# Altium schematic sheet [13] - SD CARD.SchDoc("[13] - SD CARD")
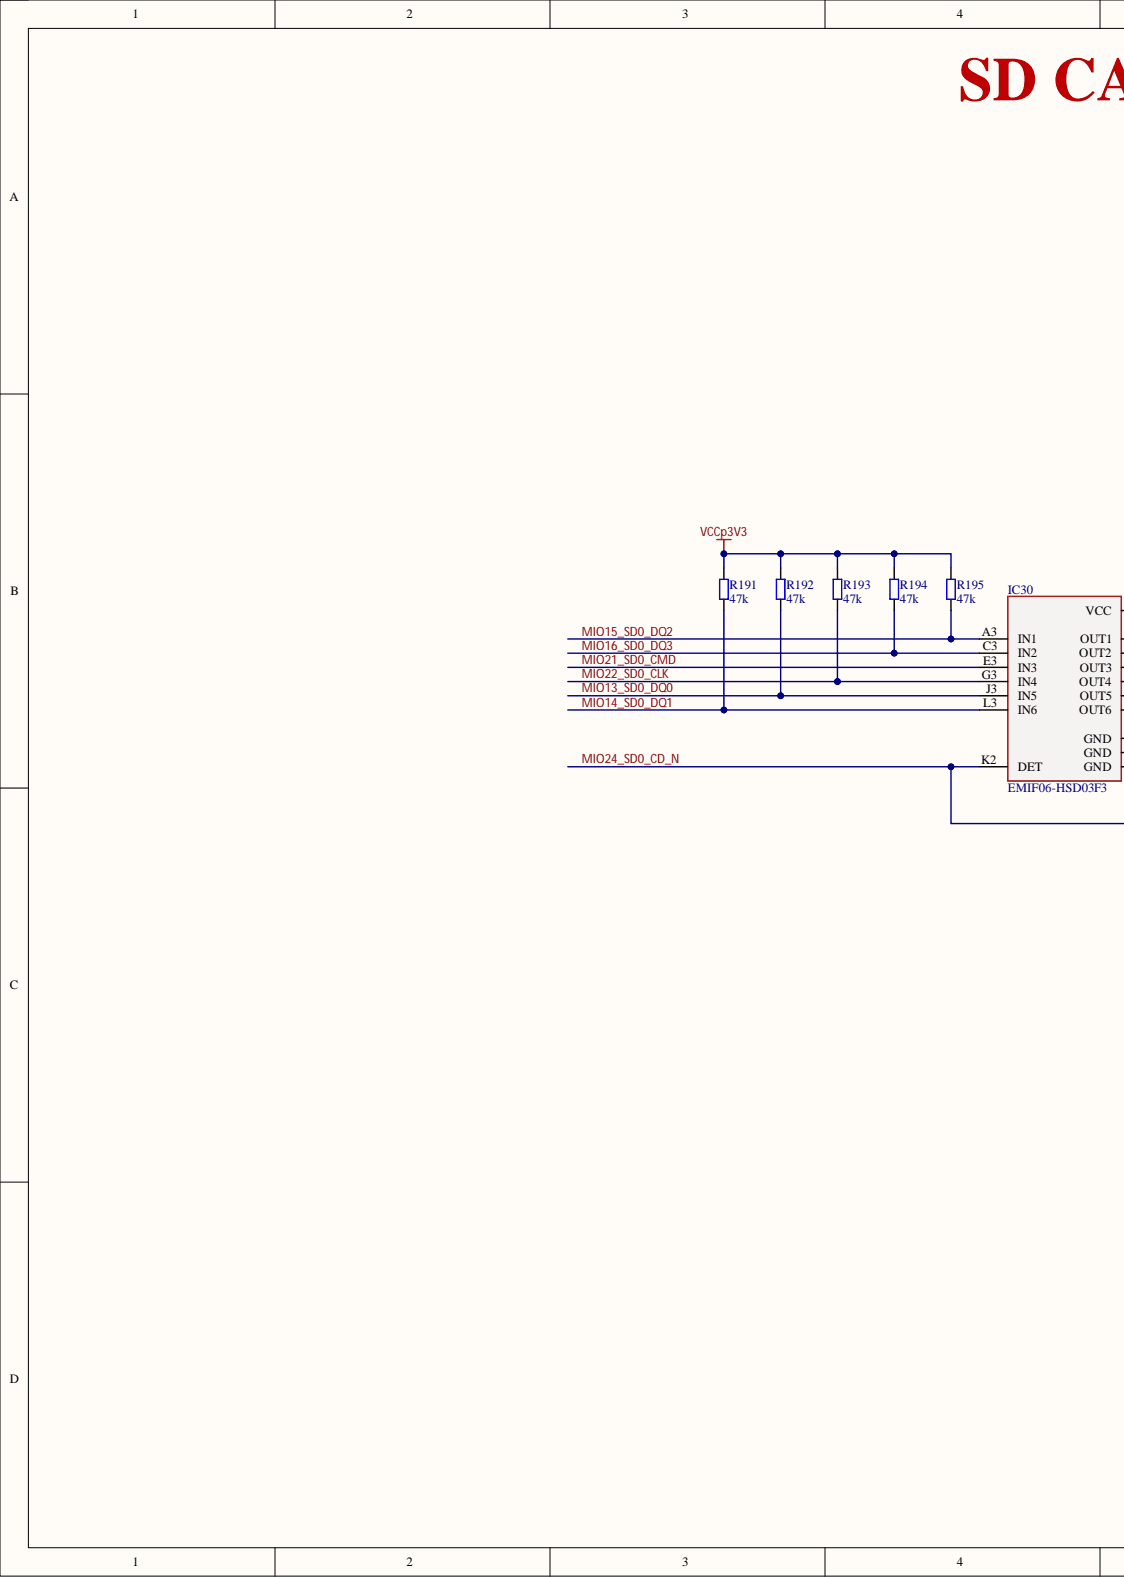
[diagram p0: [13] - SD CARD.SchDoc, left]
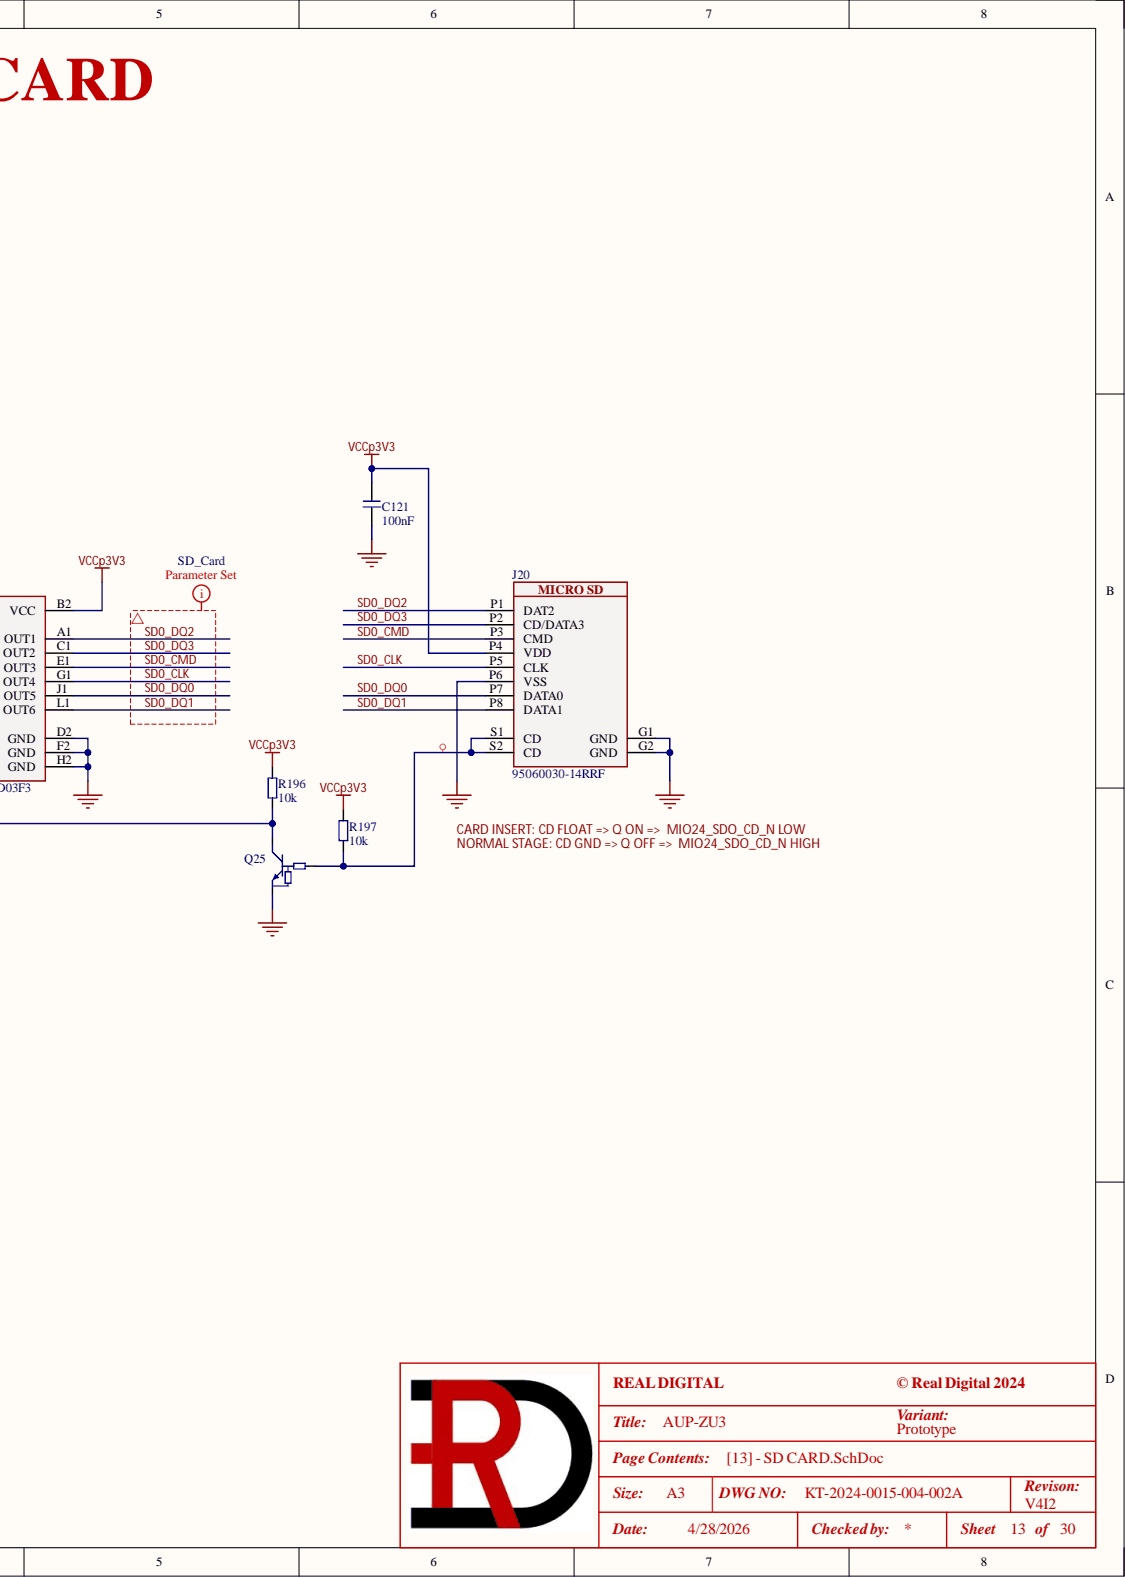
[diagram p1: [13] - SD CARD.SchDoc, right]

## Parts
C121  100nF  [C0402]
IC30  EMIF06-HSD03F3  [FLIPCHIP17_STM]
J20  95060030-14RRF  [95060030-14RRF]
Q25  DTC043ZEBTL  [SC89-EMT3F]  (value not drawn)
R191  47k  [R0402]
R192  47k  [R0402]
R193  47k  [R0402]
R194  47k  [R0402]
R195  47k  [R0402]
R196  10k  [R0402]
R197  10k  [R0402]

## Nets
GND: C121.1 IC30.D2(GND) IC30.F2(GND) IC30.H2(GND) J20.G1(GND) J20.G2(GND) J20.P6(VSS) Q25.GND
VCCp3V3: C121.2 IC30.B2(VCC) J20.P4(VDD) R191.2 R192.2 R193.2 R194.2 R195.2 R196.2 R197.2
MIO13_SD0_DQ0: IC30.J3(IN5) R192.1
MIO14_SD0_DQ1: IC30.L3(IN6) R191.1
MIO15_SD0_DQ2: IC30.A3(IN1) R195.1
MIO16_SD0_DQ3: IC30.C3(IN2) R194.1
MIO21_SD0_CMD: IC30.E3(IN3)
MIO22_SD0_CLK: IC30.G3(IN4) R193.1
MIO24_SD0_CD_N: IC30.K2(DET) Q25.OUT R196.1
SD0_CLK: IC30.G1(OUT4) J20.P5(CLK)
SD0_CMD: IC30.E1(OUT3) J20.P3(CMD)
SD0_DQ0: IC30.J1(OUT5) J20.P7(DATA0)
SD0_DQ1: IC30.L1(OUT6) J20.P8(DATA1)
SD0_DQ2: IC30.A1(OUT1) J20.P1(DAT2)
SD0_DQ3: IC30.C1(OUT2) J20.P2(CD/DATA3)
NetJ20_S1: J20.S1(CD) J20.S2(CD) Q25.IN R197.1

## Library data of the parts
C121 = GRM155R71E104KE14J: CAP CER 0.1uF 25V X7R 0402; Manufacturer 1: Murata Electronics; .Checked_PCB: AC; .Creator: RV; C P/N: RD-111-0006; LoadStatus: Load; Tolerance: 10%; Category: Capacitors; Component Kind: Standard; Library Name: KT-RealDigital.IntLib; Manufacturer 1 Part Number: GRM155R71E104KE14J; Temperature Coefficient: X7R; Voltage - Rated: 25V; .Checked_SCH: AC
IC30 = EMIF06-HSD03F3: FILTER RLC 1NH/2.5PF ESD SMD; Manufacturer 1: STMicroelectronics; .Checked_PCB: RV; C P/N: RD-126-0033; LoadStatus: Load; Category: IC; Component Kind: Standard; Library Name: KT-RealDigital.IntLib; Manufacturer 1 Part Number: EMIF06-HSD03F3; .Checked_SCH: RV; .Creator: RV
J20 = 95060030-14RRF: CONN MICRO SD CARD PUSH-PUSH R/A; Manufacturer 1: ANYTRONIC CORPORATION LIMITED; .Checked_PCB: RV; C P/N: TM-161-0002-O; LoadStatus: Load; Category: Connectors; Component Kind: Standard; Library Name: KT-RealDigital.IntLib; Manufacturer 1 Part Number: 95060030-14RRF; .Checked_SCH: RV; .Creator: RV
Q25 = DTC043ZEBTL: TRANS PREBIAS NPN 50V EMT3F; Manufacturer 1: Rohm Semiconductor; .Checked_PCB: RV; C P/N: RD-121-0006; LoadStatus: Load; Category: BJTs; Component Kind: Standard; Library Name: KT-RealDigital.IntLib; Manufacturer 1 Part Number: DTC043ZEBTL; .Checked_SCH: RV; .Creator: RV
R191-R195 = RC0402JR-0747KL: RES SMD 47K OHM 5% 1/16W 0402; Manufacturer 1: Yageo; .Checked_PCB: AC; .Creator: RV; C P/N: 101-0074; LoadStatus: Load; Tolerance: 5%/TK100; Category: Resistors; Component Kind: Standard; Library Name: KT-RealDigital.IntLib; Manufacturer 1 Part Number: RC0402JR-0747KL; .Checked_SCH: AC
R196 R197 = RC0402FR-0710KL: RES SMD 10K OHM 1% 1/16W 0402; Manufacturer 1: Yageo; .Checked_PCB: AC; .Creator: RV; C P/N: RD-101-0020; LoadStatus: Load; Tolerance: 1%/TK100; Category: Resistors; Component Kind: Standard; Library Name: KT-RealDigital.IntLib; Manufacturer 1 Part Number: RC0402FR-0710KL; .Checked_SCH: AC
